# Altium schematic sheet TopLevel.SchDoc
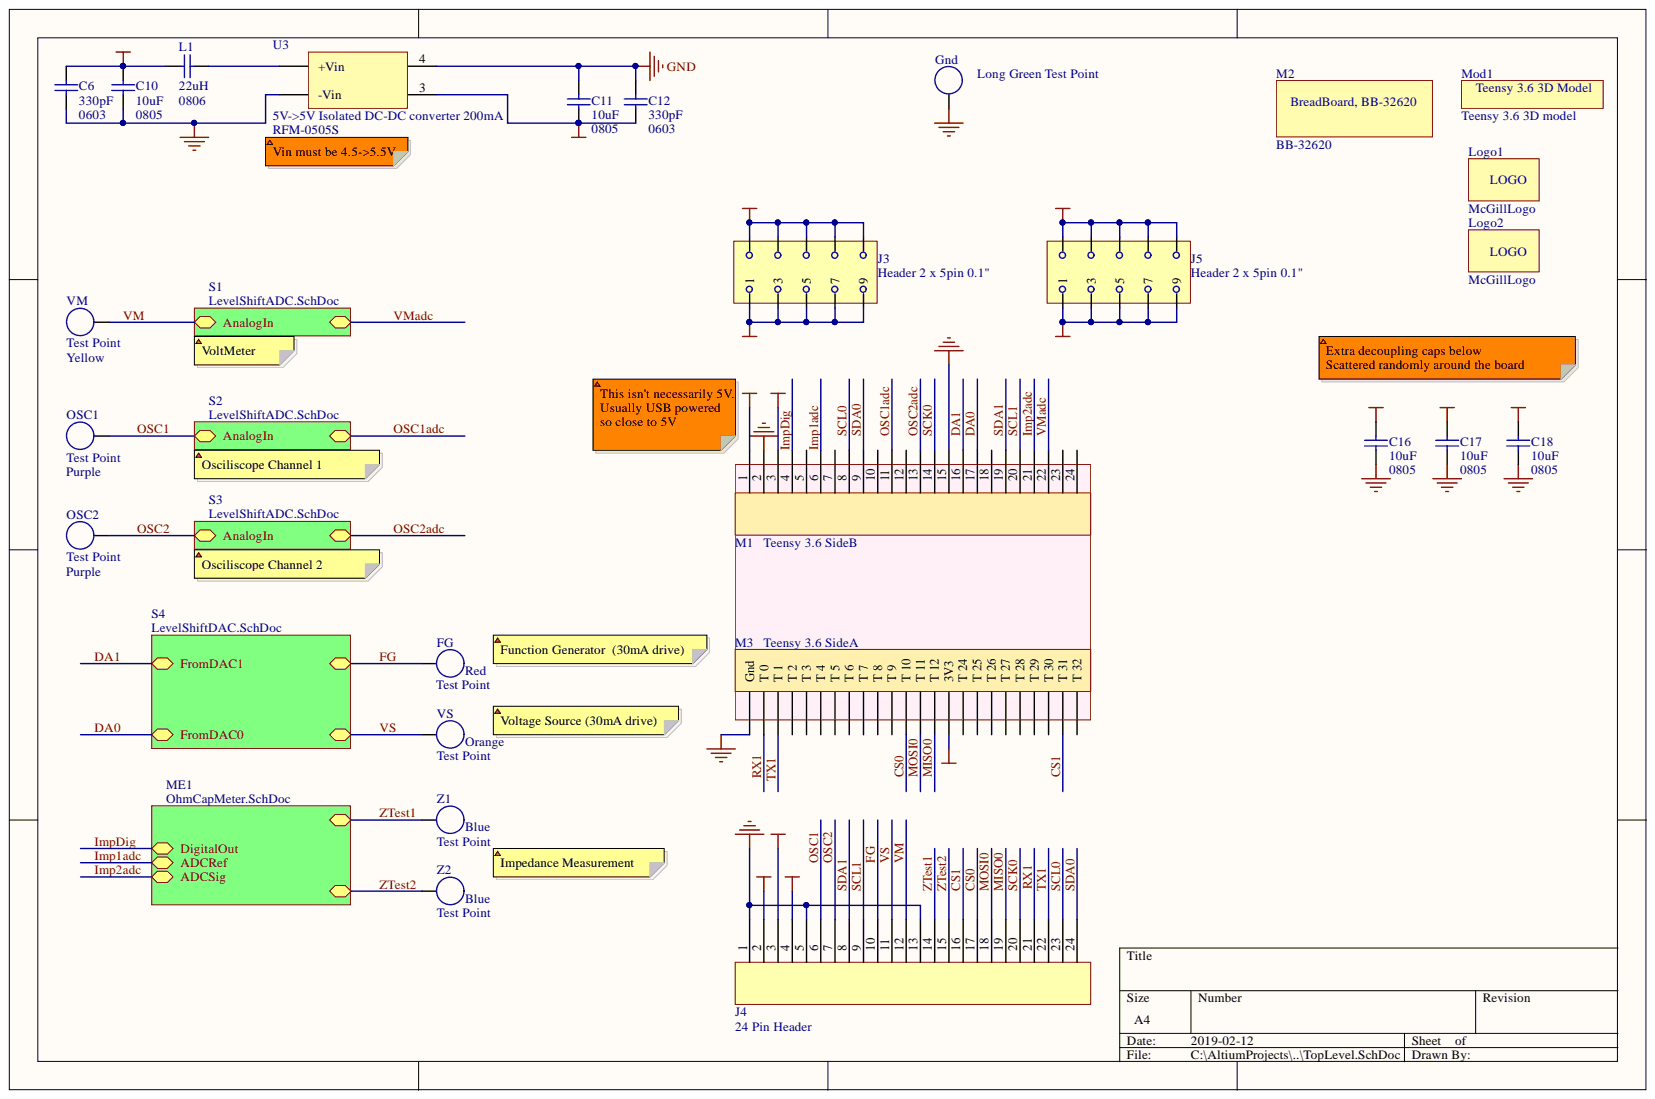
[diagram p0: TopLevel.SchDoc]

## Parts
C6  330pF  [0603]
C10  10uF  [0805]
C11  10uF  [0805]
C12  330pF  [0603]
C16  10uF  [0805]
C17  10uF  [0805]
C18  10uF  [0805]
FG  Test Point  Param: Red  [TestPoint]
Gnd  Long Green Test Point  [TestPoint]
J3  Header 2 x 5pin 0.1"
J4  24 Pin Header  [Header24Male]
J5  Header 2 x 5pin 0.1"
L1  22uH  [0806]
Logo1  McGillLogo  [McGillLogo1]  (no BOM)
Logo2  McGillLogo  [McGillLogo1]  (no BOM)
M1  Teensy 3.6 SideB  [Header24Female]
M2  BB-32620  (no BOM)
M3  Teensy 3.6 SideA  [Header24Female]
Mod1  Teensy 3.6 3D model  [Teensy3.6 Model No pins]  (no BOM)
OSC1  Test Point  Param: Purple  [TestPoint]
OSC2  Test Point  Param: Purple  [TestPoint]
U3  RFM-0505S  [RFM-0505S]
VM  Test Point  Param: Yellow  [TestPoint]
VS  Test Point  Param: Orange  [TestPoint]
Z1  Test Point  Param: Blue  [TestPoint]
Z2  Test Point  Param: Blue  [TestPoint]

## Nets
3V3: C18.2 J4.2 M1.3 M3.15(3V3)
5V: C6.1 C10.2 C16.2 J3.2 J3.4 J3.6 J3.8 J3.10 J4.3 J5.2 J5.4 J5.6 J5.8 J5.10 L1.1 M1.1
GND: C6.2 C10.1 C11.2 C12.1 C16.1 C17.1 C18.1 Gnd.0 J4.1 J4.5 J4.13 M1.2 M1.15 M3.1(Gnd) U3.1(-Vin) U3.4
N5V: C11.1 C12.2 C17.2 J3.1 J3.3 J3.5 J3.7 J3.9 J4.4 J5.1 J5.3 J5.5 J5.7 J5.9 U3.3
CS0: J4.17 M3.12(T_10)
CS1: J4.16 M3.23(T_31)
DA0: M1.17
DA1: M1.16
FG: FG.0 J4.10
Imp1adc: M1.6
Imp2adc: M1.21
ImpDig: M1.4
MISO0: J4.19 M3.14(T_12)
MOSI0: J4.18 M3.13(T_11)
OSC1: J4.6 OSC1.0
OSC1adc: M1.11
OSC2: J4.7 OSC2.0
OSC2adc: M1.13
RX1: J4.21 M3.2(T_0)
SCK0: J4.20 M1.14
SCL0: J4.23 M1.8
SCL1: J4.9 M1.20
SDA0: J4.24 M1.9
SDA1: J4.8 M1.19
TX1: J4.22 M3.3(T_1)
VM: J4.12 VM.0
VMadc: M1.22
VS: J4.11 VS.0
ZTest1: J4.14 Z1.0
ZTest2: J4.15 Z2.0
NetL1_2: L1.2 U3.2(+Vin)
NetM1_5: M1.5
NetM1_7: M1.7
NetM1_10: M1.10
NetM1_12: M1.12
NetM1_18: M1.18
NetM1_23: M1.23
NetM1_24: M1.24
NetM3_4: M3.4(T_2)
NetM3_5: M3.5(T_3)
NetM3_6: M3.6(T_4)
NetM3_7: M3.7(T_5)
NetM3_8: M3.8(T_6)
NetM3_9: M3.9(T_7)
NetM3_10: M3.10(T_8)
NetM3_11: M3.11(T_9)
NetM3_16: M3.16(T_24)
NetM3_17: M3.17(T_25)
NetM3_18: M3.18(T_26)
NetM3_19: M3.19(T_27)
NetM3_20: M3.20(T_28)
NetM3_21: M3.21(T_29)
NetM3_22: M3.22(T_30)
NetM3_24: M3.24(T_32)

## Library data of the parts
C6 C12 = Cap0603: Capacitor, Ceramic, 0603; Manufacturer: Yageo; Manufacturers Part Number: CC0603KRX7R9BB331; Assembly Comments: none; Loaded: True; Value: 330pF, 50V; Component Kind: Standard; Part constraints: CC0603KRX7R9BB331; Signal Integrity: Cap; Simulation: CAP; Supplier 1: Digi-Key; Supplier Part Number 1: 311-1186-1-ND; SupplierUnitCost: $0.0449; Library Name: projectlibrary.SchLib
C10 C11 C16-C18 = Cap0805: 0805; Manufacturer: Taiyo Yuden; Manufacturers Part Number: EMK212BJ106KG-T; Assembly Comments: none; Loaded: True; Value: 10uF 16V; Component Kind: Standard; Part constraints: EMK212BJ106KG-T; Signal Integrity: Cap; Simulation: CAP; Supplier 1: Digi-Key; Supplier Part Number 1: 587-1295-1-ND; SupplierUnitCost: $0.078; Library Name: projectlibrary.SchLib
FG = TestPoint: Manufacturer: Keystone Electronics; Part Constraints: 5005; Assembly Comments: none; Loaded: True; Component Kind: Standard; Description: Test Point; Manufacturers Part Number: Red - 5005; Supplier 1: Digi-Key; Supplier Part Number 1: 36-5005-ND; SupplierUnitCost: $0.15; Library Name: projectlibrary.SchLib
Gnd = TestPoint: Test Point; Manufacturer: Keystone Electronics; Part Constraints: 5126; Assembly Comments: none; Loaded: True; Component Kind: Standard; Manufacturers Part Number: Green - 5126; Param: Green; Supplier 1: Digi-Key; Supplier Part Number 1: 36-5126-ND; SupplierUnitCost: $0.15; Library Name: projectlibrary.SchLib
J3 J5 = Header2x5: Header, 0.1", 2 row 5 pins; Manufacturer: Wurth Electronics Inc.; Manufacturers Part Number: 61301021121; Assembly Comments: none; Loaded: True; Component Kind: Standard; Part constraints: 61301021121; Supplier 1: Digi-Key; Supplier Part Number 1: 732-2672-ND; SupplierUnitCost: $1.11012; Library Name: projectlibrary.SchLib
J4 = 24 Pin Header: Manufacturer: Wurth Electronics Inc.; Manufacturers Part Number: 61302411121; Assembly Comments: none; Loaded: True; Component Kind: Standard; Description: 24 Pin Header; Part Constraints: 61302411121; Supplier 1: Digi-Key; Supplier Part Number 1: 732-5331-ND; SupplierUnitCost: $0.93; Library Name: projectlibrary.SchLib
L1 = CBC2016T220M: 0805; Manufacturer: Taiyo Yuden; Assembly Comments: none; Loaded: True; Value: 22uH, 240mA, 2.3 Ohm; Component Kind: Standard; Manufacturers Part Number: CBC2016T220M; Part constraints: CBC2016T220M; Supplier 1: Digi-Key; Supplier Part Number 1: 587-1609-1-ND; SupplierUnitCost: $0.23; Library Name: projectlibrary.SchLib
Logo1 Logo2 = Logo: Library Name: projectlibrary.SchLib
M1 = Teensy 3.6 SideB: Teensy 3.6 SideA; Manufacturer: Sullins Connector Solutions; Manufacturers Part Number: PPPC241LFBN-RC; Assembly Comments: none; Loaded: True; Component Kind: Standard; Part constraints: PPPC241LFBN-RC; Supplier 1: Digi-Key; Supplier Part Number 1: S7057-ND; SupplierUnitCost: $1.57; Library Name: projectlibrary.SchLib
M2 = BreadBoard: Breadboard BB-32620; Manufacturer: Bud Industries; Assembly Comments: none; Loaded: True; Manufacturers Part Number: BB-32620; Part constraints: BB-32620; Supplier 1: Digi-Key; Supplier Part Number 1: 377-2091-ND; SupplierUnitCost: $9.499; Library Name: projectlibrary.SchLib
M3 = Teensy 3.6 SideA: Manufacturer: Sullins Connector Solutions; Manufacturers Part Number: PPPC241LFBN-RC; Assembly Comments: none; Loaded: True; Component Kind: Standard; Description: Teensy 3.6 SideA; Part constraints: PPPC241LFBN-RC; Supplier 1: Digi-Key; Supplier Part Number 1: S7057-ND; SupplierUnitCost: $1.57; Library Name: projectlibrary.SchLib
Mod1 = Teensy 3.6 3D model: Manufacturer: SparkFun Electronics; Manufacturers Part Number: DEV-14058; Assembly Comments: none; Loaded: True; Description: Teensy 3.6 3D model; Part Constraints: DEV-14058; Supplier 1: Digi-Key; Supplier Part Number 1: 1568-1465-ND; SupplierUnitCost: $55.61; Library Name: projectlibrary.SchLib
OSC1 OSC2 = TestPoint: Manufacturer: Keystone Electronics; Part Constraints: 5124; Assembly Comments: none; Loaded: True; Component Kind: Standard; Description: Test Point; Manufacturers Part Number: Purple - 5124; Supplier 1: Digi-Key; Supplier Part Number 1: 36-5124-ND; SupplierUnitCost: $0.15; Library Name: projectlibrary.SchLib
U3 = RFM-0505S: 5V->5V DC-DC converter; Manufacturer: Recom Power; Assembly Comments: none; Loaded: True; Component Kind: Standard; Manufacturers Part Number: RFM-0505S; Part constraints: RFM-0505S; Supplier 1: Digi-Key; Supplier Part Number 1: 945-3159-ND; SupplierUnitCost: $1.24; Library Name: projectlibrary.SchLib
VM = TestPoint: Manufacturer: Keystone Electronics; Part Constraints: 5009; Assembly Comments: none; Loaded: True; Component Kind: Standard; Description: Test Point; Manufacturers Part Number: Yellow - 5009; Supplier 1: Digi-Key; Supplier Part Number 1: 36-5009-ND; SupplierUnitCost: $0.15; Library Name: projectlibrary.SchLib
VS = TestPoint: Manufacturer: Keystone Electronics; Part Constraints: 5008; Assembly Comments: none; Loaded: True; Component Kind: Standard; Description: Test Point; Manufacturers Part Number: Orange - 5008; Supplier 1: Digi-Key; Supplier Part Number 1: 36-5008-ND; SupplierUnitCost: $0.15; Library Name: projectlibrary.SchLib
Z1 Z2 = TestPoint: Manufacturer: Keystone Electronics; Part Constraints: 5122; Assembly Comments: none; Loaded: True; Component Kind: Standard; Description: Test Point; Manufacturers Part Number: Blue - 5122; Supplier 1: Digi-Key; Supplier Part Number 1: 36-5122-ND; SupplierUnitCost: $0.15; Library Name: projectlibrary.SchLib
